# Altium schematic sheet Digital_110V.SchDoc
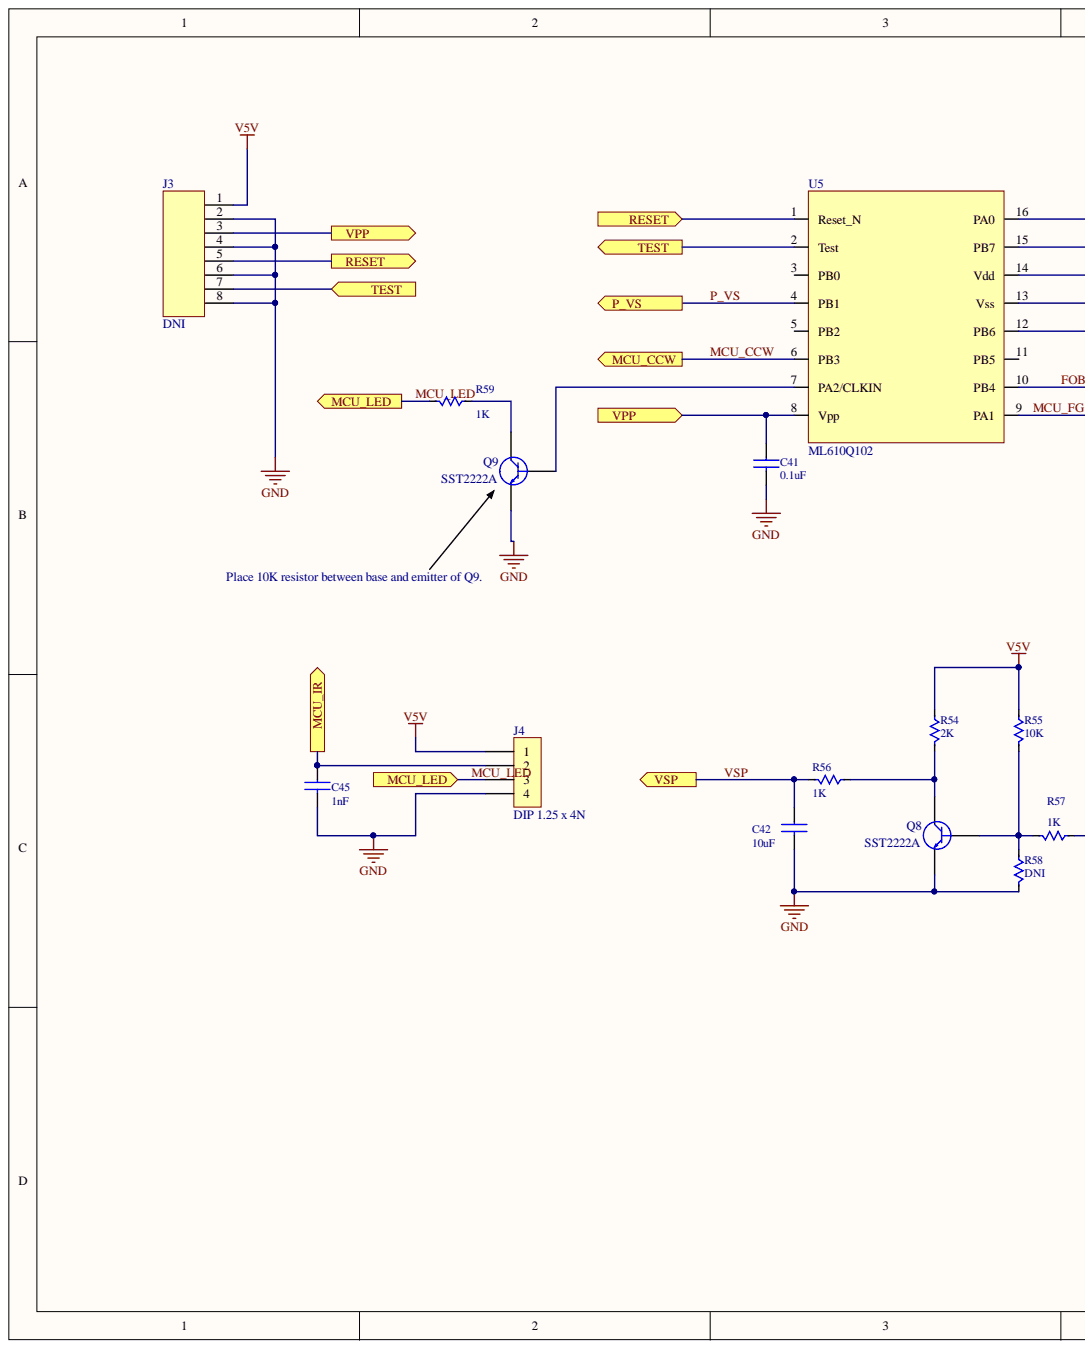
[diagram p0: Digital_110V.SchDoc, left]
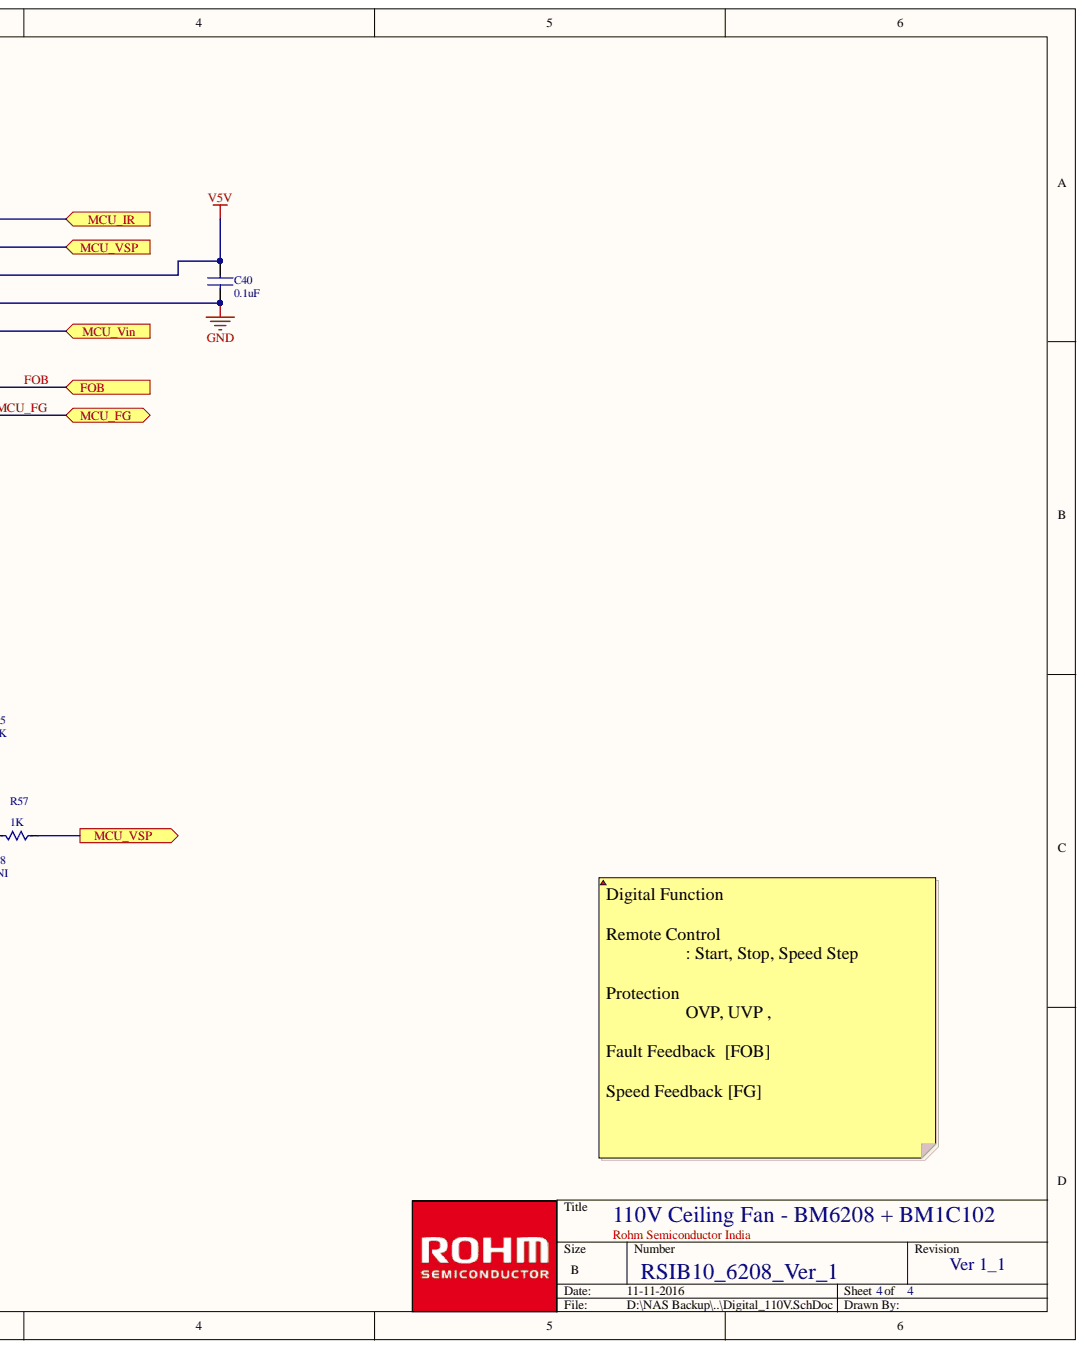
[diagram p1: Digital_110V.SchDoc, right]

## Parts
C40  0.1uF  [C0805]
C41  0.1uF  [C0805]
C42  10uF  [C0805]
C45  1nF  [C0805]
J3  DNI  value 1  [CON - 8pin -Dual in Line]
J4  DIP 1.25 x 4N  [CON_V_2.0X4]
Q8  SST2222A  [SOT-23]
Q9  SST2222A  [SOT-23]
R54  2K  [R0805]
R55  10K  [R0805]
R56  1K  [R0805]
R57  1K  [R0805]
R58  DNI  [R0805]
R59  1K  [R0805]
U5  ML610Q102  [SSOP65P640X125-16N]

## Ports
FOB, MCU_CCW, MCU_FG, MCU_IR, MCU_LED, MCU_VIN, MCU_VSP, P_VS, RESET, TEST, VPP, VSP

## Nets
GND: C40.2 C41.2 C42.2 C45.2 J3.2 J3.4 J3.6 J3.8 J4.4 Q8.2 Q9.2 R58.2 U5.13(Vss)
V5V: C40.1 J3.1 J4.1 R54.1 R55.1 U5.14(Vdd)
FOB: U5.10(PB4) ; port FOB
MCU_CCW: U5.6(PB3) ; port MCU_CCW
MCU_FG: U5.9(PA1) ; port MCU_FG
MCU_IR: C45.1 J4.2 U5.16(PA0) ; port MCU_IR
MCU_LED: J4.3 R59.2 ; port MCU_LED
MCU_VSP: R57.1 U5.15(PB7) ; port MCU_VSP
P_VS: U5.4(PB1) ; port P_VS
RESET: J3.5 U5.1(Reset_N) ; port RESET
TEST: J3.7 U5.2(Test) ; port TEST
VPP: C41.1 J3.3 U5.8(Vpp) ; port VPP
VSP: C42.1 R56.2 ; port VSP
NetQ8_1: Q8.1 R55.2 R57.2 R58.1
NetQ8_3: Q8.3 R54.2 R56.1
NetQ9_1: Q9.1 U5.7(PA2/CLKIN)
NetQ9_3: Q9.3 R59.1
NetU5_12: U5.12(PB6) ; port MCU_VIN

Not connected: U5 3(PB0) 5(PB2) 11(PB5)

## Library data of the parts
C40-C42 C45 = CAP NP: Section: Digital; Type: SMD_Cap; Value: 0.004615; Component Kind: Standard; Supplier 1: *Wurth; Supplier Part Number 1: 885 012 207 072; Library Name: Altium_Ara_Sch_libraries_R1.SchLib
J3 = CON8: Connector; Component Kind: Standard; Library Name: Altium_Ara_Sch_libraries_R1.SchLib
J4 = CON4: CONN SOCKET HOUSING 4POS 1.25MM; Section: Digital; Value: .05; Component Kind: Standard; Supplier 1: Hirose; Supplier Part Number 1: DF13-4P-1.25DSA; Type: Connector; Library Name: Altium_Ara_Sch_libraries_R1.SchLib
Q8 Q9 = NPN: NPN Transistor; Section: Inverter; Type: Trans/FET; Value: 0.00940; Component Kind: Standard; Supplier 1: *Rohm; Supplier Part Number 1: SST2222AMGT116; Library Name: Altium_Ara_Sch_libraries_R1.SchLib
R54-R59 = R0805: Resistor; Section: Digital; Value: 0.00126; Component Kind: Standard; Type: SMD_resistor; Library Name: Altium_Ara_Sch_libraries_R1.SchLib
  R54: Supplier 1: *Rohm; Supplier Part Number 1: MCR10EZPF2001
  R55: Supplier 1: *Rohm; Supplier Part Number 1: MCR10EZPF1002
  R56 R57 R59: Supplier 1: *Rohm; Supplier Part Number 1: MCR10EZPF1001
  R58: Supplier 1: Rohm; Supplier Part Number 1: DNI
U5 = ML610Q102: Section: Digital; Value: 0.33200; Component Kind: Standard; Supplier 1: *Rohm; Supplier Part Number 1: ML610Q102-NNNMBZ0ATL; Type: IC; Library Name: Altium_Ara_Sch_libraries_R1.SchLib
